# Altium schematic sheet Heart_Rate_Sensor.SchDoc(Heart_Rate_Sensor)
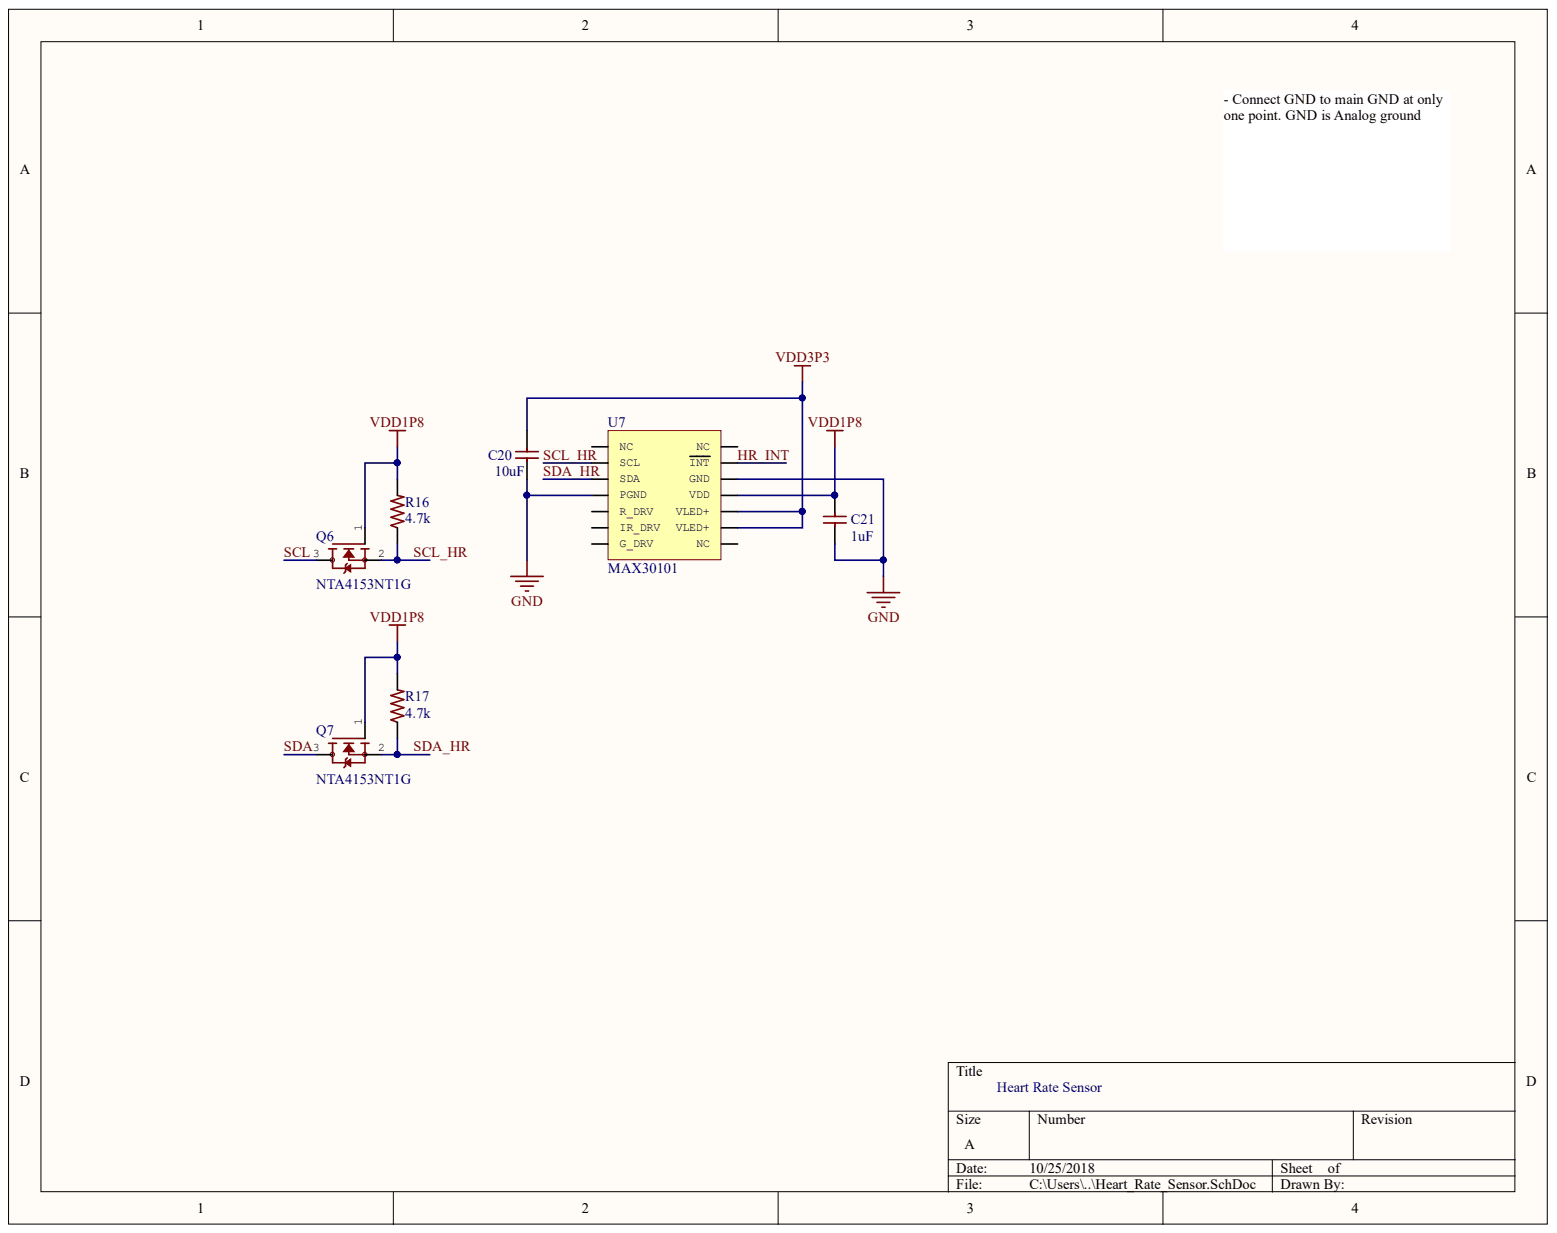
[diagram p0: Heart_Rate_Sensor.SchDoc]

## Parts
C20  10uF  [CAP0603]
C21  1uF  [CAP0603]
Q6  NTA4153NT1G  [SC-75]
Q7  NTA4153NT1G  [SC-75]
R16  4.7k  [RES0603]
R17  4.7k  [RES0603]
U7  MAX30101  [MAX30101]

## Nets
GND: C20.1 C21.1 U7.4(PGND) U7.12(GND)
VDD1P8: C21.2 Q6.1 Q7.1 R16.2 R17.2 U7.11(VDD)
VDD3P3: C20.2 U7.9(VLED+) U7.10(VLED+)
HR_INT: U7.13(INT)
SCL: Q6.3
SCL_HR: Q6.2 R16.1 U7.2(SCL)
SDA: Q7.3
SDA_HR: Q7.2 R17.1 U7.3(SDA)

Not connected: U7 1(NC) 5(R_DRV) 6(IR_DRV) 7(G_DRV) 8(NC) 14(NC)

## Library data of the parts
C20 C21 = Capacitor: Component Kind: Standard; Library Name: karo_components.IntLib
Q6 Q7 = NTA4153NT1G: N Channel MOSFET; Component Kind: Standard; Library Name: karo_components.IntLib
R16 R17 = Resistor: Component Kind: Standard; Library Name: karo_components.IntLib
U7 = MAX30101: Heart rate sensor; Component Kind: Standard; Library Name: karo_components.IntLib
